# Altium schematic sheet HAL_5.SchDoc
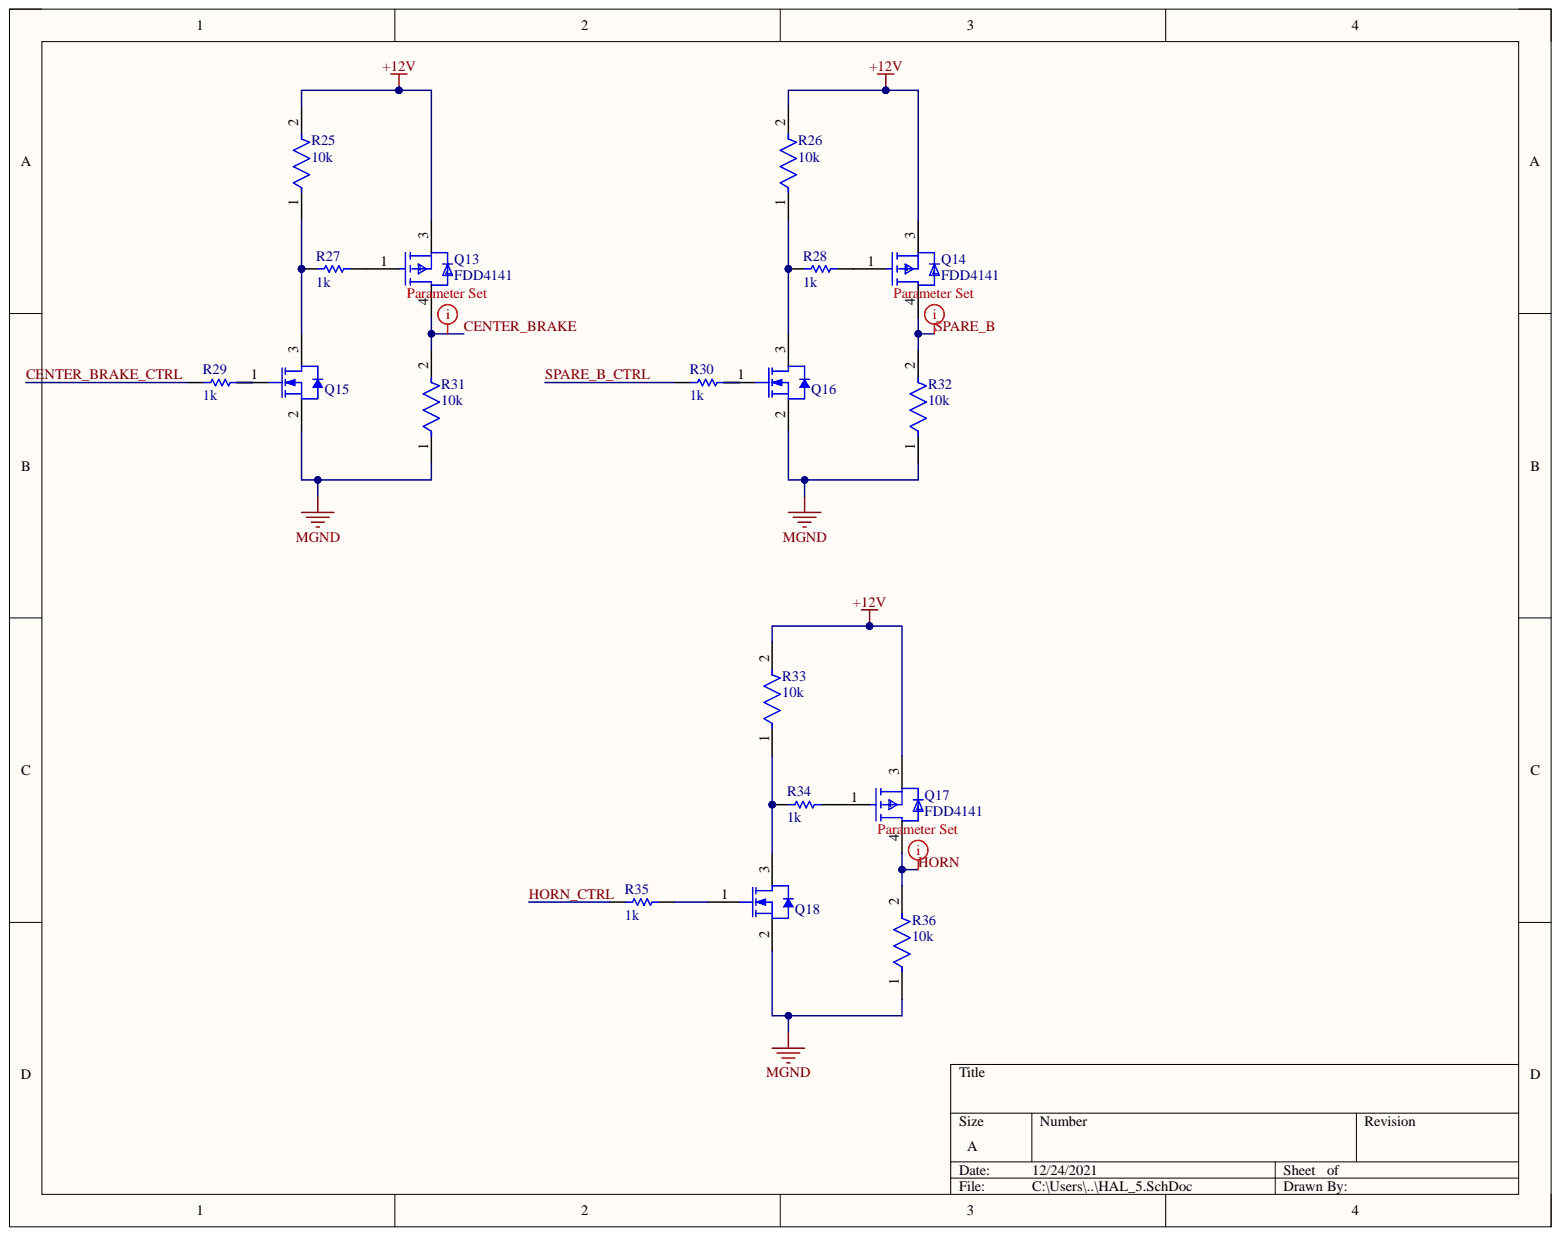
[diagram p0: HAL_5.SchDoc]

## Parts
Q13  FDD4141  [FP-369AS-MFG]
Q14  FDD4141  [FP-369AS-MFG]
Q15  NTR4501NT1G  [ONSC-SOT-23-3-318-08_V]  (value not drawn)
Q16  NTR4501NT1G  [ONSC-SOT-23-3-318-08_V]  (value not drawn)
Q17  FDD4141  [FP-369AS-MFG]
Q18  NTR4501NT1G  [ONSC-SOT-23-3-318-08_V]  (value not drawn)
R25  10k  [FP-RMCF0805-MFG]
R26  10k  [FP-RMCF0805-MFG]
R27  1k  [RESC3216X70X40LL20T20]
R28  1k  [RESC3216X70X40LL20T20]
R29  1k  [RESC3216X70X40LL20T20]
R30  1k  [RESC3216X70X40LL20T20]
R31  10k  [FP-RMCF0805-MFG]
R32  10k  [FP-RMCF0805-MFG]
R33  10k  [FP-RMCF0805-MFG]
R34  1k  [RESC3216X70X40LL20T20]
R35  1k  [RESC3216X70X40LL20T20]
R36  10k  [FP-RMCF0805-MFG]

## Nets
+12V: Q13.3 Q14.3 Q17.3 R25.2 R26.2 R33.2
MGND: Q15.2 Q16.2 Q18.2 R31.1 R32.1 R36.1
CENTER_BRAKE: Q13.4 R31.2
CENTER_BRAKE_CTRL: R29.1
HORN: Q17.4 R36.2
HORN_CTRL: R35.1
SPARE_B: Q14.4 R32.2
SPARE_B_CTRL: R30.1
NetQ13_1: Q13.1 R27.2
NetQ14_1: Q14.1 R28.2
NetQ15_1: Q15.1 R29.2
NetQ15_3: Q15.3 R25.1 R27.1
NetQ16_1: Q16.1 R30.2
NetQ16_3: Q16.3 R26.1 R28.1
NetQ17_1: Q17.1 R34.2
NetQ18_1: Q18.1 R35.2
NetQ18_3: Q18.3 R33.1 R34.1

## Library data of the parts
Q13 Q14 Q17 = CMP-07173-000088-1: MOSFET P-CH 40V 10.8A DPAK; Manufacturer: ON Semiconductor; Continuous Drain Current: -10.8A; Depletion Mode: False; Drain To Source Breakdown Voltage: -40V; Drain To Source Resistance: 10.1mΩ; Gate Charge At Vgs: 36nC @ -10V; Gate To Source Voltage: 20V; Input Capacitace At Vds: 2085pF @ -20V; Max Forward Diode Voltage: -1.2V; Max Junction Temp: +150°C; Number Of Channels: 1; Power Dissipation: 2.4W; Pulse Drain Current: -100A; Reverse Recovery Charge: 26nC; Reverse Recovery Time: 29ns; Rthja Max: 52°C/W; Threshold Vgs Max: -3V; Threshold Vgs Min: -1V; Transconductance: 38S; Turn Off Delay Time: 38ns; Turn On Delay Time: 10ns; Typ Forward Diode Voltage: -0.8V; Automotive: No; Category: Diode; Component Kind: Standard; ComponentLink2Description: Datasheet; ComponentLink2URL: https://www.onsemi.com/pub/Collateral/FDD4141-D.pdf; Device Class L1: Discrete Semiconductors; Device Class L2: Transistors; Device Class L3: MOSFETs; Digikey Description: MOSFET P-CH 40V 10.8A DPAK; Drain To Source Voltage: -40V; Footprint Url: https://www.onsemi.com/pub/Collateral/369AS.PDF; Height: 2.517mm; Lead Free: Yes; Library Name: Altium Content Vault; Manufacturer Part Number: FDD4141; Mouser Description: MOSFET -40V P-Channel PowerTrench; Number Of P Channels: 1; Octopart: https://octopart.com/fdd4141-on+semiconductor-84325802; Package: 369AS; RoHS: TRUE; Temperature: -55°C to +150°C; Temperature Range High: +150°C; Temperature Range Low: -55°C
Q15 Q16 Q18 = CMP-1058-00732-1: Power MOSFET, 20 V, 3.2 A, Single N-Channel, 3-Pin SOT-23, Pb-Free, Tape and Reel; Manufacturer: On Semiconductor; Channel Polarity: N Channel; Ciss Typ (pF): 200; Coss Typ (pF): 80; Crss Typ (pF): 50; PD Max (W): 1.25; Qrr Typ (nC): 3; V(BR)DSS Min (V): 20; VGS Max (V): 12; VGS(th) Max (V): 1.2; Component Kind: Standard; ComponentLink1Description: Manufacturer URL; ComponentLink1URL: http://www.onsemi.com/; ComponentLink2Description: Datasheet; ComponentLink2URL: http://www.onsemi.cn/pub_link/Collateral/NTR4501N-D.PDF; ComponentLink3Description: Package Specification; ComponentLink3URL: http://www.onsemi.cn/pub_link/Collateral/318-08.PDF; Configuration: Single; DatasheetVersion: Rev. 13; Library Name: Altium Content Vault; Mounting Technology: Surface Mount; Package Type: SOT-23-3; PackageDescription: 3-Pin Small Outline Transistor, Body 2.92 x 1.3 mm, Pitch 0.95 mm; PackageReference: SOT-23-3-318-08; PackageVersion: Rev. AP, 11/2009; Packing: Tape and Reel; PartNumber: NTR4501NT1G; RoHS: Pb-Free
R25 R26 R31-R33 R36 = CMP-26527-000121-1: RES 10K OHM 1% 1/8W 0805; Max Power Dissipation: 125mW; Resistance: 10kR; Temperature Coefficient: 100ppm/°C; Case Code (Imperial): 0805; Case Code (Metric): 2012; Case/Package: 0805; Component Kind: Standard; Construction: Rectangular; Contact Plating: Tin; Depth: 1.25mm; Features: Automotive AEC-Q200; Height: 0.026inch; Lead Free: Lead Free; Length: 2mm; Library Name: Altium Content Vault; Max Operating Temperature: 155°C; Military Standard: Not; Min Operating Temperature: -55°C; Mount: Surface Mount; Number of Terminations: 2; Packaging: Tape and Reel; Power Rating: 125mW; Radiation Hardening: No; Resistor Type: Thick Film; RoHS Compliant: Yes; Series: RMCF; Size Code: 0805; Tolerance: 1%; Width: 0.049inch
R27-R30 R34 R35 = CMP-2003-04517-1: RK73B2BTTD102J; Manufacturer: KOA Speer; Component Kind: Standard; Library Name: Altium Content Vault; Part Number: RK73B2BTTD102J
